# Altium schematic sheet Sheet1.SchDoc
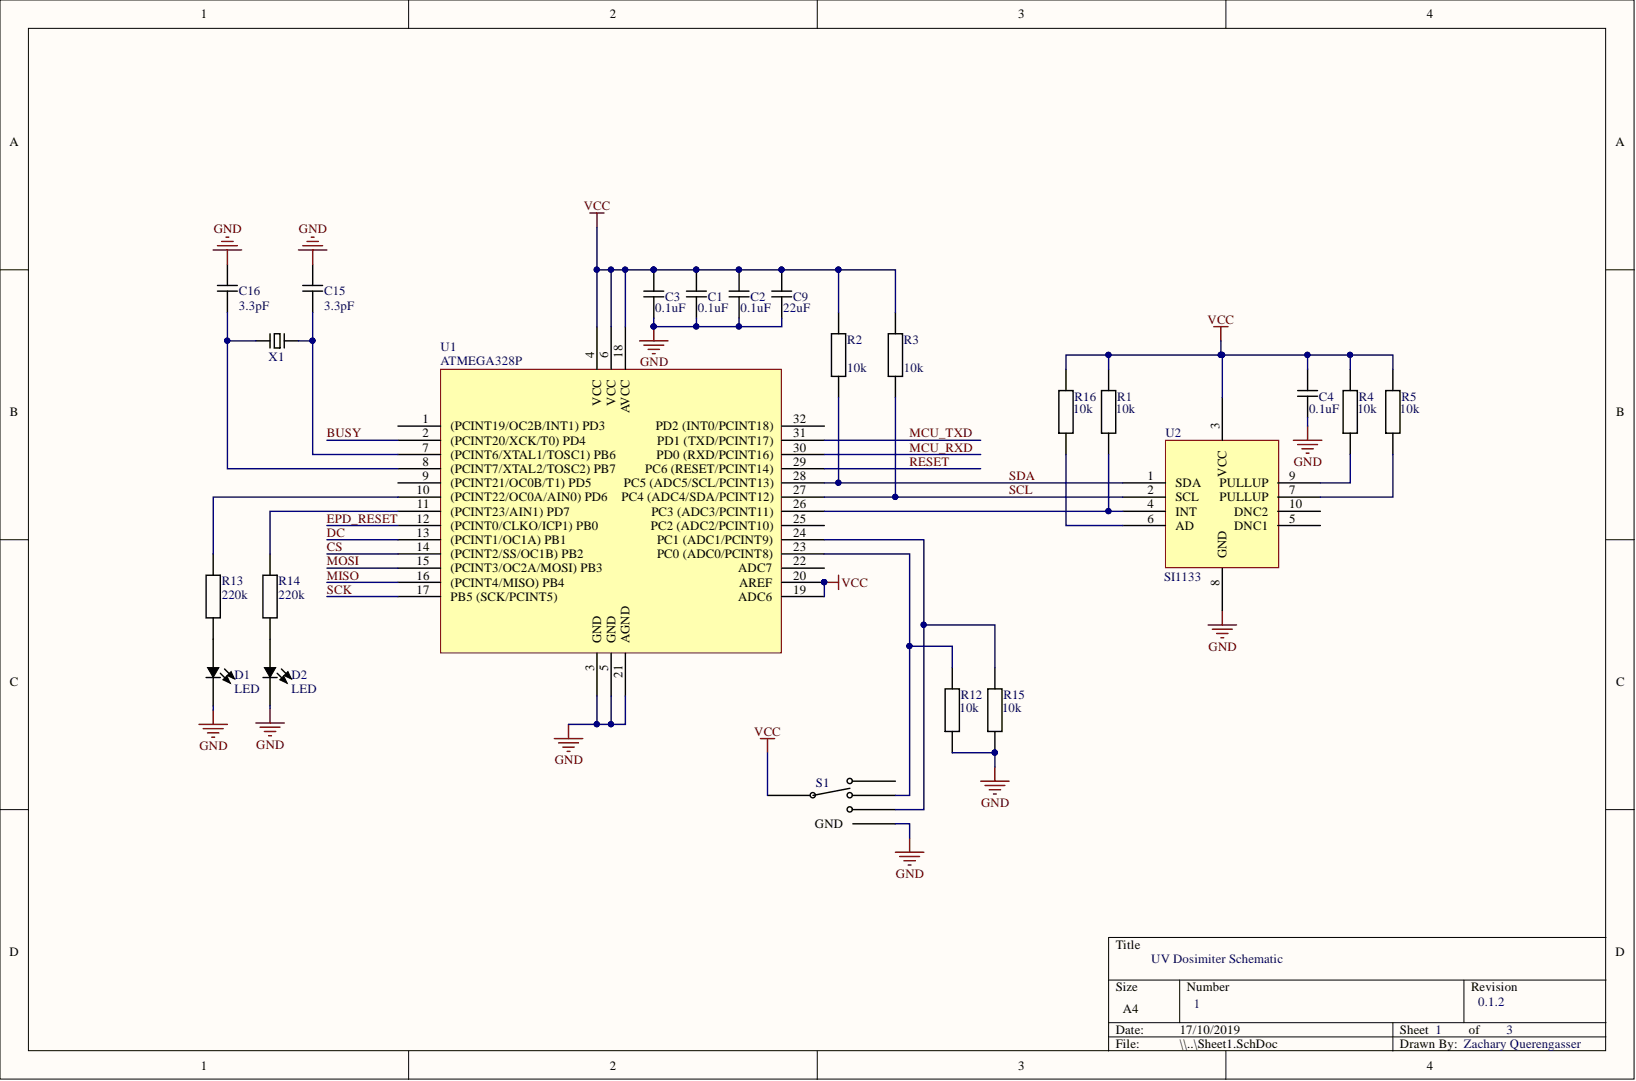
[diagram p0: Sheet1.SchDoc]

## Parts
C1  value 0.1uF  [CAPC1005X06M]  (hidden comment: CAP)
C2  value 0.1uF  [CAPC0603X03M]  (hidden comment: CAP)
C3  value 0.1uF  [CAPC0603X03M]  (hidden comment: CAP)
C4  value 0.1uF  [CAPC1005X06M]  (hidden comment: CAP)
C9  value 22uF  [CAPC1608X10]  (hidden comment: CAP)
C15  value 3.3pF  [CAPC1005X06M]  (hidden comment: CAP)
C16  value 3.3pF  [CAPC1005X06M]  (hidden comment: CAP)
D1  LED  [0805R]
D2  LED  [0805R]
R1  value 10k  [0805R]  (hidden comment: RES)
R2  value 10k  [0805R]  (hidden comment: RES)
R3  RES  value 10k  [0805R]
R4  value 10k  [0805R]  (hidden comment: RES)
R5  value 10k  [0805R]  (hidden comment: RES)
R12  value 10k  [0805R]  (hidden comment: RES)
R13  value 220k  [0805R]  (hidden comment: RES)
R14  value 220k  [0805R]  (hidden comment: RES)
R15  value 10k  [0805R]  (hidden comment: RES)
R16  value 10k  [0805R]  (hidden comment: RES)
S1  sp3t slide  [sp3t slide (smd)]  (value not drawn)
U1  ATMEGA328P  [TQFP80P900X900X120-32M]
U2  SI1133  [QFN50P200X200X80-10M]
X1  XTAL  (value not drawn)

## Nets
GND: C1.2 C2.2 C3.2 C4.1 C9.1 C15.1 C16.1 D1.2 D2.2 R12.1 R15.1 S1.5(GND) U1.3(GND) U1.5(GND) U1.21(AGND) U2.8(GND)
VCC: C1.1 C2.1 C3.1 C4.2 C9.2 R1.2 R2.2 R3.2 R4.2 R5.2 R16.2 S1.3 U1.4(VCC) U1.6(VCC) U1.18(AVCC) U1.19(ADC6) U1.20(AREF) U2.3(VCC)
BUSY: U1.2((PCINT20/XCK/T0)_PD4)
CS: U1.14((PCINT2/SS/OC1B)_PB2)
DC: U1.13((PCINT1/OC1A)_PB1)
EPD_RESET: U1.12((PCINT0/CLKO/ICP1)_PB0)
MCU_RXD: U1.30(PD0_(RXD/PCINT16))
MCU_TXD: U1.31(PD1_(TXD/PCINT17))
MISO: U1.16((PCINT4/MISO)_PB4)
MOSI: U1.15((PCINT3/OC2A/MOSI)_PB3)
RESET: U1.29(PC6_(RESET/PCINT14))
SCK: U1.17(PB5_(SCK/PCINT5))
SCL: R3.1 U1.27(PC4_(ADC4/SDA/PCINT12)) U2.2(SCL)
SDA: R2.1 U1.28(PC5_(ADC5/SCL/PCINT13)) U2.1(SDA)
NetC15_2: C15.2 U1.7((PCINT6/XTAL1/TOSC1)_PB6) X1.2
NetC16_2: C16.2 U1.8((PCINT7/XTAL2/TOSC2)_PB7) X1.1
NetD1_1: D1.1 R13.2
NetD2_1: D2.1 R14.2
NetR1_1: R1.1 U1.26(PC3_(ADC3/PCINT11)) U2.4(INT)
NetR4_1: R4.1 U2.9(PULLUP)
NetR5_1: R5.1 U2.7(PULLUP)
NetR12_2: R12.2 S1.2 U1.23(PC0_(ADC0/PCINT8))
NetR13_1: R13.1 U1.10((PCINT22/OC0A/AIN0)_PD6)
NetR14_1: R14.1 U1.11((PCINT23/AIN1)_PD7)
NetR15_2: R15.2 S1.4 U1.24(PC1_(ADC1/PCINT9))
NetR16_1: R16.1 U2.6(AD)

Not connected: S1 1; U1 1((PCINT19/OC2B/INT1)_PD3) 9((PCINT21/OC0B/T1)_PD5) 22(ADC7) 25(PC2_(ADC2/PCINT10)) 32(PD2_(INT0/PCINT18)); U2 5(DNC1) 10(DNC2)

## Library data of the parts
C1-C4 C9 C15 C16 = CAP: Component Kind: Standard; Library Name: Custom.IntLib; Signal Integrity: Guessed Model
D1 D2 = LED: Component Kind: Standard; Library Name: Custom.IntLib
R1-R5 R12-R16 = RES: Component Kind: Standard; Library Name: Custom.IntLib; Signal Integrity: Guessed Model
S1 = sp3t slide: Component Kind: Standard; Library Name: Custom.SchLib
U1 = ATMEGA328P: Component Kind: Standard; Library Name: Custom.SchLib
U2 = SI1133: Component Kind: Standard; Library Name: Custom.SchLib
X1 = XTAL: Component Kind: Standard; Library Name: Custom.IntLib
